# Altium schematic sheet MB1280.SchDoc(MB1280)
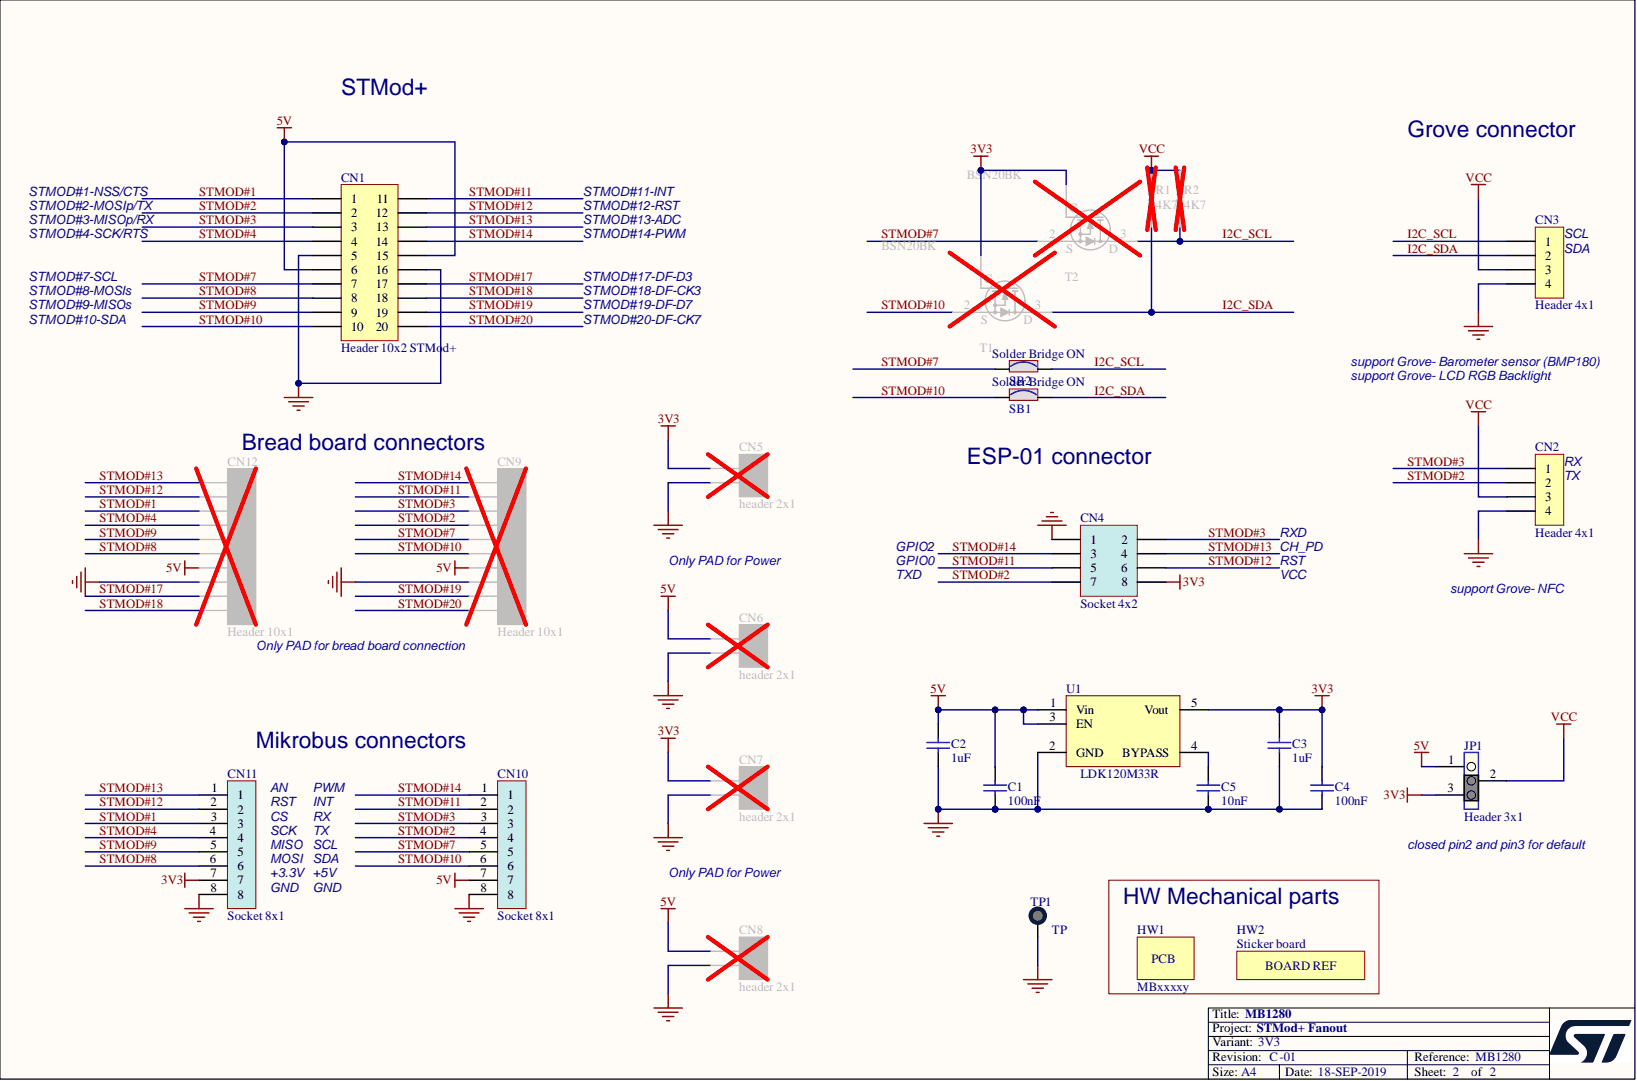
[diagram p0: MB1280.SchDoc]

## Parts
C1  value 100nF  [C0603]  (hidden comment: Capacitor)
C2  value 1uF  [C0603_H0P8]  (hidden comment: Capacitor)
C3  value 1uF  [C0603_H0P8]  (hidden comment: Capacitor)
C4  value 100nF  [C0603]  (hidden comment: Capacitor)
C5  value 10nF  [C0603]  (hidden comment: Capacitor)
CN1  Header 10x2 STMod+  [CON_SAMTEC_TMM-110-01-L-D-RA__STMOD+]
CN2  Header 4x1  [CON_988-JIUBABA_A2006LF-04A]
CN3  Header 4x1  [CON_988-JIUBABA_A2006LF-04A]
CN4  Socket 4x2  [CON_SAMTEC_SSW-104-01-X-D]
CN5  Header 2x1  [CON_988-JIUBABA_PHFS25-11-02-G0B115-T-1]
CN6  Header 2x1  [CON_988-JIUBABA_PHFS25-11-02-G0B115-T-1]
CN7  Header 2x1  [CON_988-JIUBABA_PHFS25-11-02-G0B115-T-1]
CN8  Header 2x1  [CON_988-JIUBABA_PHFS25-11-02-G0B115-T-1]
CN9  Header 10x1  [CON_SAMTEC_TSW-110-14-G-S]
CN10  Socket 8x1  [CON_SAMTEC_SSW-108-01-X-S]
CN11  Socket 8x1  [CON_SAMTEC_SSW-108-01-X-S]
CN12  Header 10x1  [CON_SAMTEC_TSW-110-14-G-S]
HW1  PCB  value MBxxxxy  (no BOM)
HW2  value Sticker board  [STICKER_25X9]  (hidden comment: STICKER_BOARD_REF)  (no BOM)
JP1  value Header 3x1  [CON_SAMTEC_TSW-103-07-F-S]  (hidden comment: Jumper_Header 3x1 Fitted 2-3)
R1  value 4K7  [R0603]  (hidden comment: Resistor)
R2  value 4K7  [R0603]  (hidden comment: Resistor)
SB1  value Solder Bridge ON  [SOLDER-BRIDGE_R0603-0R]  (hidden comment: SB_ON)
SB2  value Solder Bridge ON  [SOLDER-BRIDGE_R0603-0R]  (hidden comment: SB_ON)
T1  BSN20  value BSN20BK  Manufacturer_Part_Number: BSN20BK  [MOS_SOT23]
T2  BSN20  value BSN20BK  Manufacturer_Part_Number: BSN20BK  [MOS_SOT23]
TP1  value TP  [TP_PTH_1MM_2MM]  (hidden comment: Test_Point_PTH_1mm_2mm)  (no BOM)
U1  LDK120M33R  [REG_SOT23-5L]

## Nets
3V3: C3.2 C4.2 CN4.8 CN5.1 CN7.1 CN11.7 JP1.3 T1.1 T2.1 U1.5(Vout)
5V: C1.2 C2.2 CN1.6 CN1.15 CN6.1 CN8.1 CN9.7 CN10.7 CN12.7 JP1.1 U1.1(Vin) U1.3(EN)
GND: C1.1 C2.1 C3.1 C4.1 C5.1 CN1.5 CN1.16 CN2.4 CN3.4 CN4.1 CN5.2 CN6.2 CN7.2 CN8.2 CN9.8 CN10.8 CN11.8 CN12.8 TP1.1 U1.2(GND)
VCC: CN2.3 CN3.3 JP1.2 R1.1 R2.1
I2C_SCL: CN3.1 R2.2 SB2.2 T2.3
I2C_SDA: CN3.2 R1.2 SB1.2 T1.3
STMOD#1: CN1.1 CN11.3 CN12.3
STMOD#2: CN1.2 CN2.2 CN4.7 CN9.4 CN10.4
STMOD#3: CN1.3 CN2.1 CN4.2 CN9.3 CN10.3
STMOD#4: CN1.4 CN11.4 CN12.4
STMOD#7: CN1.7 CN9.5 CN10.5 SB2.1 T2.2
STMOD#8: CN1.8 CN11.6 CN12.6
STMOD#9: CN1.9 CN11.5 CN12.5
STMOD#10: CN1.10 CN9.6 CN10.6 SB1.1 T1.2
STMOD#11: CN1.11 CN4.5 CN9.2 CN10.2
STMOD#12: CN1.12 CN4.6 CN11.2 CN12.2
STMOD#13: CN1.13 CN4.4 CN11.1 CN12.1
STMOD#14: CN1.14 CN4.3 CN9.1 CN10.1
STMOD#17: CN1.17 CN12.9
STMOD#18: CN1.18 CN12.10
STMOD#19: CN1.19 CN9.9
STMOD#20: CN1.20 CN9.10
NetC5_2: C5.2 U1.4(BYPASS)

## Library data of the parts
C1 C4 = MCD-00211: Capacitor, MLCC, 100nF, 16V, X7R, 10%, 0603, T=0,8mm; Manufacturer: YAGEO; Manufacturer_Part_Number: CC0603KRX7R7BB104; Part_Preference: Recommended; Component_Country_Of_Origin: China; Database Table Name: MCD_PARTS$; ECCN_EU: NEC; ECCN_US: EAR99; ID: 2659; Order_Code_RS: 669-8763; Provided_By: SUBCO; ShowFields: YES; Component Kind: Standard; ComponentLink1Description: Datasheet; ComponentLink1URL: http://tunmcdsvn.tun.st.com/Component_Documentation_Library/Trunk/Capacitor/YAGEO_X7R_Series; Internal_Part_Number: MCD-00211; Library Name: MCD_PARTS_database.DbLib; Order_Code_Digikey: 311-1088-1-ND; Order_Code_Farnell: 432210; Package: 0603; Part_Type: Capacitor; Status: Released
C2 C3 = MCD-01703: Capacitor,1uF,50V,X5R,10%,0603, T=0.8mm; Manufacturer: YAGEO; Manufacturer_Part_Number: CC0603KRX5R9BB105; Part_Preference: Recommended; Component_Country_Of_Origin: China; Database Table Name: MCD_PARTS$; ID: 3803; Provided_By: SUBCO; ShowFields: YES; Component Kind: Standard; ComponentLink1Description: Datasheet; ComponentLink1URL: http://tunmcdsvn.tun.st.com/Component_Documentation_Library/Trunk/Capacitor/YAGEO_X5R_Series; ECCN_EU: N/A; ECCN_US: N/A; Internal_Part_Number: MCD-01703; Library Name: MCD_PARTS_database.DbLib; Order_Code_Digikey: 311-1787-1-ND; Order_Code_Farnell: N/A; Order_Code_RS: N/A; Package: 0603; Part_Type: Capacitor; Status: Released
C5 = MCD-00017: Capacitor, MLCC, 10nF, 50V, X7R, 10%, 0603, T=0.8mm; Manufacturer: YAGEO; Manufacturer_Part_Number: CC0603KRX7R9BB103; Part_Preference: Recommended; Component_Country_Of_Origin: China; Database Table Name: MCD_PARTS$; ECCN_EU: NEC; ECCN_US: EAR99; ID: 2500; Order_Code_RS: 616-9537; Provided_By: SUBCO; ShowFields: YES; Component Kind: Standard; ComponentLink1Description: Datasheet; ComponentLink1URL: http://tunmcdsvn.tun.st.com/Component_Documentation_Library/Trunk/Capacitor/YAGEO_X7R_Series; Internal_Part_Number: MCD-00017; Library Name: MCD_PARTS_database.DbLib; Order_Code_Digikey: 311-1085-1-ND; Order_Code_Farnell: 722236; Package: 0603; Part_Type: Capacitor; Status: Released
CN1 = MCD-00288: Header 10x2, 2mm, RA, TH, Height 3.20mm/3.50mm; Alternate_Manufacturer: JIUBABA; Alternate_Manufacturer_Part_Number: PH200210C-07000; Manufacturer: SAMTEC; Manufacturer_Part_Number: TMM-110-01-L-D-RA; Part_Preference: Recommended; Part_Type: Connector; Component_Country_Of_Origin: China, Costa Rica, Malaysia, United States, Vietnam; Database Table Name: MCD_PARTS$; ID: 2581; Order_Code_RS: 180-3469; Provided_By: SUBCO; ShowFields: YES; Component Kind: Standard; ComponentLink1Description: Datasheet; ComponentLink1URL: http://tunmcdsvn.tun.st.com/Component_Documentation_Library/Trunk/Connector/SAMTEC_TMM(TH)_Series/; ECCN_EU: n/a; ECCN_US: n/a; Internal_Part_Number: MCD-00288; Library Name: MCD_PARTS_database.DbLib; Order_Code_Digikey: SAM10228-ND; Order_Code_Farnell: 3515054; Status: Released; Value: Header 10x2 STMod+
CN2 CN3 = MCD-01741: Header 4x1, 2mm, VR, SMT; Manufacturer: Jiubaba; Manufacturer_Part_Number: A2006LF-04A; Part_Preference: Alternate; Part_Type: Connector; Partial_Alternate_Internal_Part_Number: MCD-03083; Component_Country_Of_Origin: Unknown; Database Table Name: MCD_PARTS$; ID: 3841; Provided_By: SUBCO; ShowFields: YES; Component Kind: Standard; ComponentLink1Description: Datasheet; ComponentLink1URL: http://tunmcdsvn.tun.st.com/Component_Documentation_Library/Trunk/Connector/JIUBABA_A2006LF-04A/; ECCN_EU: N/A; ECCN_US: N/A; Internal_Part_Number: MCD-01741; Library Name: MCD_PARTS_database.DbLib; Order_Code_Digikey: N/A; Order_Code_Farnell: N/A; Order_Code_RS: N/A; Status: Released; Value: Header 4x1
CN4 = MCD-01742: Socket 4x2,2.54mm,VR,TH, height 8.51/2.64mm; Alternate_Manufacturer: Jiubaba; Alternate_Manufacturer_Part_Number: 218108S1610; Manufacturer: SAMTEC; Manufacturer_Part_Number: SSW-104-01-G-D; Part_Preference: Recommended; Part_Type: Connector; Component_Country_Of_Origin: China, Costa Rica, Malaysia, United States, Vietnam; Database Table Name: MCD_PARTS$; ID: 3842; Order_Code_RS: 765-5626; Provided_By: SUBCO; ShowFields: YES; Component Kind: Standard; ComponentLink1Description: Datasheet; ComponentLink1URL: http://tunmcdsvn.tun.st.com/Component_Documentation_Library/Trunk/Connector/SAMTEC_SSW-SSQ(TH)_Series/; ECCN_EU: N/A; ECCN_US: N/A; Internal_Part_Number: MCD-01742; Library Name: MCD_PARTS_database.DbLib; Order_Code_Digikey: SAM1208-04-ND; Order_Code_Farnell: 1668340; Status: Released; Value: Socket 4x2
CN5-CN8 = MCD-00314: Header, 2x1, 2.54mm, VR, TH, Height 6mm/3mm; Manufacturer: JIUBABA; Manufacturer_Part_Number: PHFS25-11-02-G0B115-T-1; Part_Preference: Alternate; Part_Type: Connector; Alternate_EMS: A; Component_Country_Of_Origin: Unknown; Database Table Name: MCD_PARTS$; ID: 2554; Provided_By: SUBCO; ShowFields: YES; Component Kind: Standard; ComponentLink1Description: Datasheet; ComponentLink1URL: http://tunmcdsvn.tun.st.com/Component_Documentation_Library/Trunk/Connector/JIUBABA_PHFS25-11-02-G0B115-T-1/; ECCN_EU: n/a; ECCN_US: n/a; Internal_Part_Number: MCD-00314; Library Name: MCD_PARTS_database.DbLib; Order_Code_Digikey: N/A; Order_Code_Farnell: N/A; Order_Code_RS: N/A; Status: Released; Value: header 2x1
CN9 CN12 = MCD-01591: Header 10x1, 2,54mm height  10.67mm; Manufacturer: SAMTEC; Manufacturer_Part_Number: TSW-110-14-G-S; Part_Preference: Recommended; Part_Type: Connector; Component_Country_Of_Origin: China, Costa Rica, Malaysia, United States, Vietnam; Database Table Name: MCD_PARTS$; ID: 3691; Order_Code_RS: 180-1017; Provided_By: SUBCO; ShowFields: YES; Component Kind: Standard; ComponentLink1Description: Datasheet; ComponentLink1URL: http://tunmcdsvn.tun.st.com/Component_Documentation_Library/Trunk/Connector/SAMTEC_TSW_Series/; ECCN_EU: n/a; ECCN_US: n/a; Internal_Part_Number: MCD-01591; Library Name: MCD_PARTS_database.DbLib; Order_Code_Digikey: SAM1053-10-ND; Order_Code_Farnell: 2037185; Status: Checked; Value: Header 10x1
CN10 CN11 = MCD-01743: Socket 8x1, 2.54mm, VR, TH, height 8.51/2.64mm; Alternate_Manufacturer: Jiubaba; Alternate_Manufacturer_Part_Number: 988.08.18.2.00050 (218108S16198); Manufacturer: SAMTEC; Manufacturer_Part_Number: SSW-108-01-G-S; Part_Preference: Recommended; Part_Type: Connector; Component_Country_Of_Origin: China, Costa Rica, Malaysia, United States, Vietnam; Database Table Name: MCD_PARTS$; ID: 3843; Order_Code_RS: 765-5698; ShowFields: YES; Component Kind: Standard; ComponentLink1URL: http://tunmcdsvn.tun.st.com/Component_Documentation_Library/Trunk/Connector/SAMTEC_SSW-SSQ(TH)_Series/; Internal_Part_Number: MCD-01743; Library Name: MCD_PARTS_database.DbLib; Order_Code_Digikey: SAM1209-08-ND; Order_Code_Farnell: 1668363; Status: Released; Value: Socket 8x1
HW1 = MCD-00216: PCB, xxxx for PCB number, Y for PCB revision; Part_Preference: Forbidden; Part_Type: Misc; Database Table Name: MCD_PARTS$; ID: 2653; Provided_By: SUBCO; ShowFields: YES; ComponentLink1Description: Datasheet; ECCN_EU: n/a; ECCN_US: n/a; Internal_Part_Number: MCD-00216; Library Name: MCD_PARTS_database.DbLib; Manufacturer: n/a; Manufacturer_Part_Number: n/a; Status: Released
HW2 = MCD-00235: Sticker to mention board reference + serial number; Part_Preference: Recommended; Part_Type: Misc; Database Table Name: MCD_PARTS$; ID: 2632; Provided_By: SUBCO; ShowFields: YES; ComponentLink1Description: Datasheet; ComponentLink1URL: n/a; ECCN_EU: n/a; ECCN_US: n/a; Internal_Part_Number: MCD-00235; Library Name: MCD_PARTS_database.DbLib; Manufacturer: n/a; Manufacturer_Part_Number: n/a; Order_Code_Digikey: N/A; Order_Code_Farnell: N/A; Order_Code_RS: N/A; Status: Released
JP1 = MCD-00685: Header, 3x1, 2,54mm, VR, TH, Height 5.84mm/2.54mm - Shunt Fitted 2-3 by default; Manufacturer: SAMTEC; Manufacturer_Part_Number: TSW-103-07-F-S; Part_Preference: Recommended; Part_Type: Connector; Component_Country_Of_Origin: China, Costa Rica, Malaysia, United States, Vietnam; Database Table Name: MCD_PARTS$; ID: 3058; Order_Code_RS: 161-2248; Provided_By: SUBCO; ShowFields: YES; Component Kind: Standard; ComponentLink1Description: Datasheet; ComponentLink1URL: http://tunmcdsvn.tun.st.com/Component_Documentation_Library/Trunk/Connector/SAMTEC_TSW_Series/; ECCN_EU: n/a; ECCN_US: n/a; Internal_Part_Number: MCD-00685; Library Name: MCD_PARTS_database.DbLib; Order_Code_Digikey: SAM8995-ND; Order_Code_Farnell: 2505043; Status: Released
R1 R2 = MCD-00219: Resistor,4K7,0603,1%,1/10W; Manufacturer: YAGEO; Manufacturer_Part_Number: RC0603FR-074K7L; Part_Preference: Recommended; Component_Country_Of_Origin: China; Database Table Name: MCD_PARTS$; ECCN_EU: NEC; ECCN_US: EAR99; ID: 2648; Provided_By: SUBCO; ShowFields: YES; Component Kind: Standard; ComponentLink1Description: Datasheet; ComponentLink1URL: http://tunmcdsvn.tun.st.com/Component_Documentation_Library/Trunk/Resistor/YAGEO_RC_L_Series; Internal_Part_Number: MCD-00219; Library Name: MCD_PARTS_database.DbLib; Order_Code_Digikey: 311-4.70KHRCT-ND; Order_Code_Farnell: 9238565; Order_Code_RS: N/A; Package: 0603; Part_Type: Resistor; Status: Released
SB1 SB2 = MCD-00071: Solder Bridge ON; Manufacturer: YAGEO; Manufacturer_Part_Number: RC0603JR-070RL; Part_Preference: Recommended; Part_Type: Misc; Database Table Name: MCD_PARTS$; ID: 2521; Provided_By: SUBCO; ShowFields: YES; Component Kind: Standard; ComponentLink1Description: Datasheet; ComponentLink1URL: http://tunmcdsvn.tun.st.com/Component_Documentation_Library/Trunk/Resistor/YAGEO_RC_Series; ECCN_EU: n/a; ECCN_US: n/a; Internal_Part_Number: MCD-00071; Library Name: MCD_PARTS_database.DbLib; Order_Code_Digikey: N/A; Order_Code_Farnell: N/A; Order_Code_RS: N/A; Package: SB0603; Status: Released
T1 T2 = MCD-01969: N-channel enhancement mode field-effect transistor; Manufacturer: Nexperia; Part_Preference: Recommended; Component_Country_Of_Origin: China; Database Table Name: MCD_PARTS$; ECCN_EU: NEC; ECCN_US: EAR99; ID: 4069; Order_Code_RS: 136-4845; Provided_By: SUBCO; ShowFields: YES; Component Kind: Standard; ComponentLink1Description: Datasheet; ComponentLink1URL: http://tunmcdsvn.tun.st.com/Component_Documentation_Library/Trunk/Transistor/NEXPERIA_BSN20BK; Internal_Part_Number: MCD-01969; Library Name: MCD_PARTS_database.DbLib; Order_Code_Digikey: 1727-2341-1-ND; Order_Code_Farnell: 2575103; Package: BSN20BK; Part_Type: Transistor; Status: Released
TP1 = MCD-01506: Test Point trought hole 1mm/2mm; Part_Preference: Recommended; Part_Type: Misc; Database Table Name: MCD_PARTS$; ID: 3606; ShowFields: YES; ComponentLink1Description: Datasheet; ComponentLink1URL: n/a; ECCN_EU: n/a; ECCN_US: n/a; Internal_Part_Number: MCD-01506; Library Name: MCD_PARTS_database.DbLib; Manufacturer: N/A; Manufacturer_Part_Number: N/A; Provided_By: n/a; Status: Released
U1 = MCD-00663: 200 mA low quiescent current very low noise LDO; BMS_Part_Number: 9176330; Manufacturer: STMICROELECTRONICS; Manufacturer_HQ_COO: EU; Part_Preference: Recommended; Part_Type: IC; Partial_Alternate_Internal_Part_Number: MCD-03279; Component_Country_Of_Origin: China; Database Table Name: MCD_PARTS$; ECCN_EU: NEC; ECCN_US: EAR99; ID: 3082; Order_Code_RS: 188-9202; Provided_By: SUBCO with ST control; ShowFields: YES; Component Kind: Standard; ComponentLink1Description: Datasheet; ComponentLink1URL: http://tunmcdsvn.tun.st.com/Component_Documentation_Library/Trunk/IC/STMICROELECTRONICS_LDK120M33R; Internal_Part_Number: MCD-00663; Library Name: MCD_PARTS_database.DbLib; Manufacturer_Part_Number: LDK120M33R; Order_Code_Digikey: 497-13500-2-ND; Order_Code_Farnell: N/A; Package: SOT23-5L; Status: Released; Value: LDK120M33R
